# Altium schematic sheet InductiveSensor.SchDoc
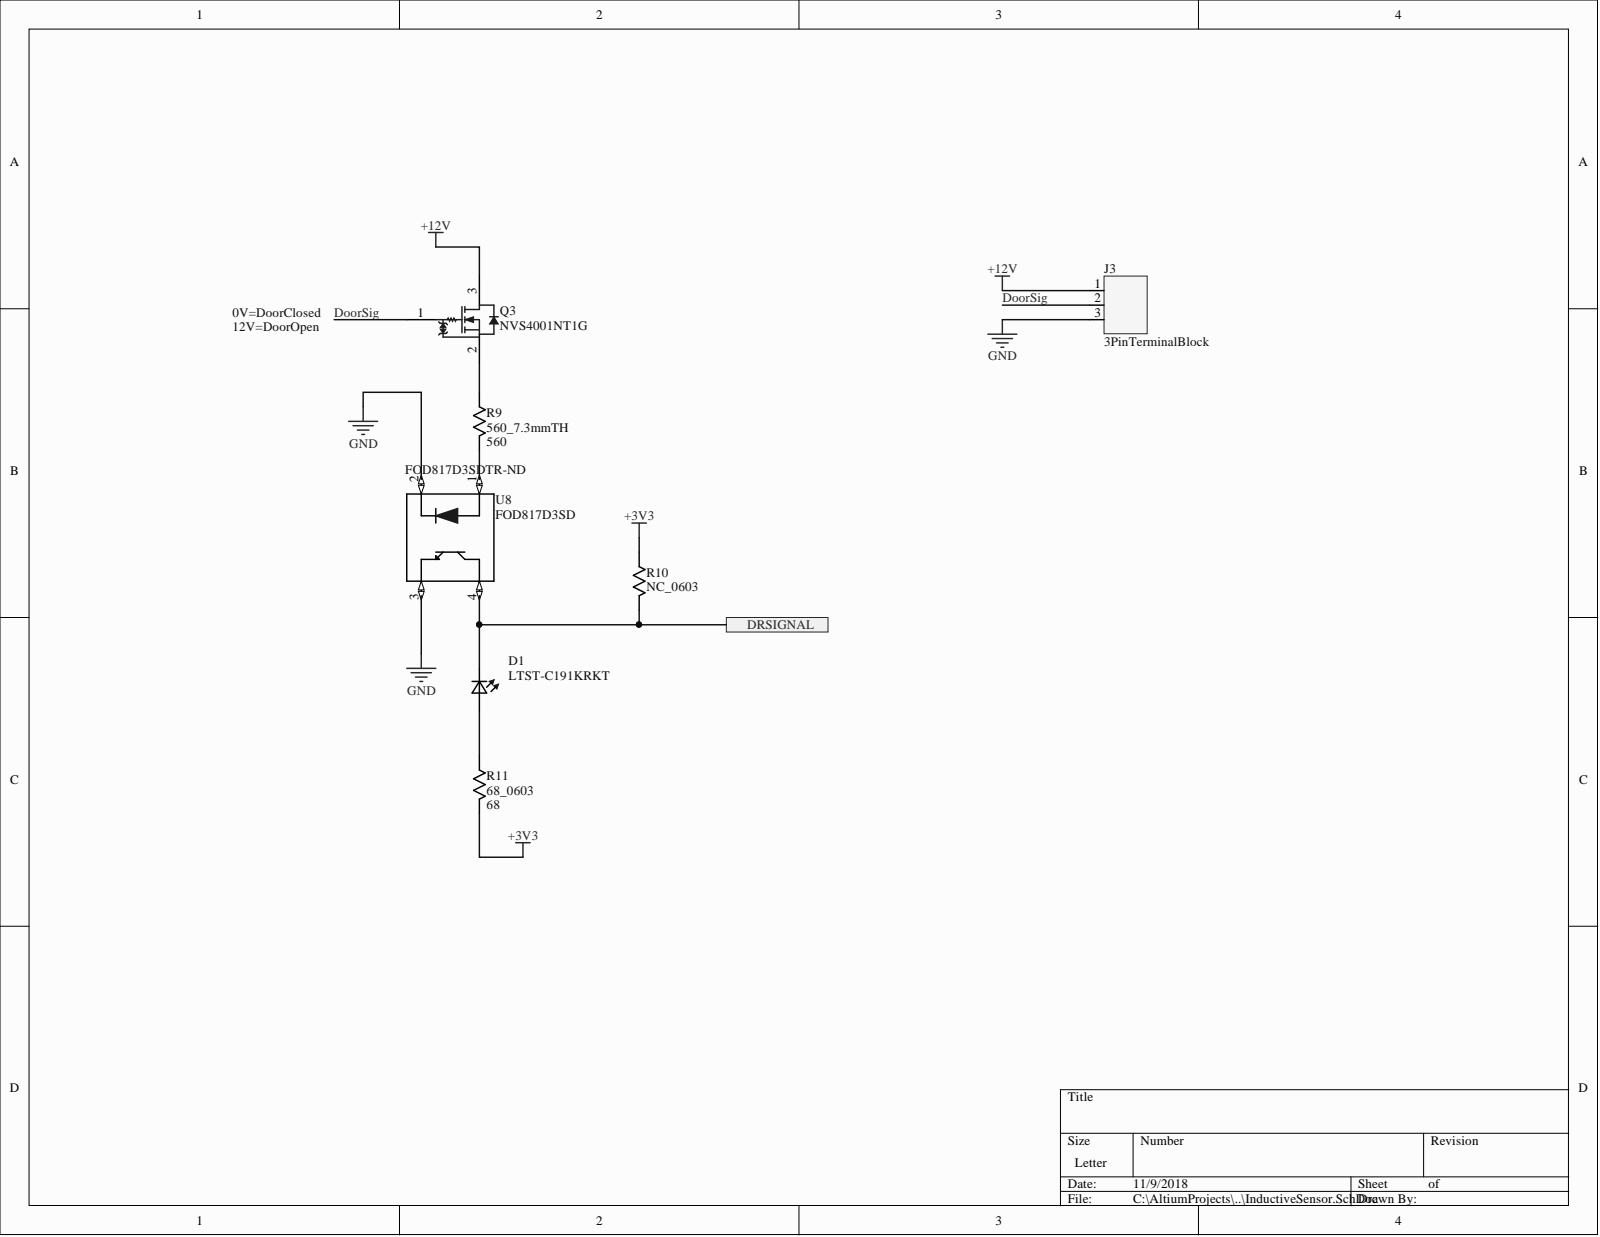
[diagram p0: InductiveSensor.SchDoc]

## Parts
D1  LTST-C191KRKT  [0603]
J3  3PinTerminalBlock  [3 POS 5mm Pitch]
Q3  NVS4001NT1G  [ONSC-SC-70-3-419-04_V]
R9  560_7.3mmTH  [7.3mm Through Hole]
R10  NC_0603  [0603]
R11  68_0603  [0603]
U8  FOD817D3SD  Supplier Part Number 1: FOD817D3SDTR-ND  [FOD817D3SD]

## Ports
DRSIGNAL

## Nets
+3V3: R10.2 R11.1
+12V: J3.1 Q3.3
GND: J3.3 U8.2 U8.3
DoorSig: J3.2 Q3.1
DRSIGNAL: D1.1 R10.1 U8.4 ; port DRSIGNAL
NetD1_2: D1.2 R11.2
NetQ3_2: Q3.2 R9.2
NetR9_1: R9.1 U8.1

## Library data of the parts
D1 = LTST-C191KRKT: LED; SMD; 0603; red; 18-54mcd; 1.6x0.8x0.55mm; 130Â°; 2Ã·2.4V; 20mA; MF: Lite-On; Availability: Good; Component Kind: Standard; Library Name: Diodes.IntLib; MP: LTST-C191KRKT; Package: 0603 Lite-On; Price: 0.06 USD; SnapEDA_Link: https://www.snapeda.com/parts/LTST-C191KRKT/Lite-On/view-part/?ref=snap
J3 = 3PinTerminalBlock: 3 Position terminal block; Component Kind: Standard; Library Name: Connectors.IntLib
Q3 = NVS4001NT1G: Small Signal MOSFET, 30 V, 270 mA, N-Channel, 3-Pin SC70, Pb-Free, Tape and Reel; Manufacturer: On Semiconductor; Channel Polarity: N Channel; Ciss Typ (pF): 20; Configuration: Single; Coss Typ (pF): 19; Crss Typ (pF): 7.25; ID Max (A): 0.27; PD Max (W): 0.33; V(BR)DSS Min (V): 30; VGS(th) Max (V): 1.5; Component Kind: Standard; ComponentLink1Description: Manufacturer URL; ComponentLink1URL: http://www.onsemi.com/; ComponentLink2Description: Datasheet; ComponentLink2URL: http://www.onsemi.cn/pub_link/Collateral/NTS4001N-D.PDF; ComponentLink3Description: Package Specification; ComponentLink3URL: http://www.onsemi.cn/pub_link/Collateral/419-04.PDF; DatasheetVersion: Rev. 5; Library Name: ON Semi MOSFETs.IntLib; Mounting Technology: Surface Mount; PackageDescription: 3-Pin Small Outline Transistor, Body 2 x 1.25 mm, Pitch 0.65 mm; PackageReference: SC-70-3-419-04; Package Type: SC-70-3; PackageVersion: Rev. N, 11/2008; Packing: Tape and Reel; PartNumber: NVS4001NT1G; RoHS: Pb-Free; Supplier 1: Newark; Supplier 2: Mouser; Supplier Part Number 1: 60W1862; Supplier Part Number 2: 863-NVS4001NT1G; VGS Max (V): 20
R9 = 560_7.3mmTH: Manufacturer: Stackpole Electronics; Manufacturer Part Number: CFM12JT560R; Max Power Dissipation: 500mW; Resistance: 560; Temperature Coefficient: -400ppm/°C; Tolerance: 5%; Case/Package: Axial; Component Kind: Standard; ComponentLink1Description: https://www.seielect.com/Catalog/SEI-CF_CFM.pdf; ComponentLink1URL: https://www.seielect.com/Catalog/SEI-CF_CFM.pdf; Composition: Carbon Film; Contact Plating: Tin,Matte; Diameter: 2.3mm; Lead Free: Lead Free; Length: 0.256inch; Library Name: Resistors.IntLib; Max Operating Temperature: 155°C; Min Operating Temperature: -55°C; Mount: Through Hole,Axial; Number of Terminations: 2; Package Quantity: 5000; Packaging: Tape and Reel; Pricing 1: 5000=0.00703, 10000=0.00611, 25000=0.00537, 50000=0.00492, 125000=0.00482 (USD); Radiation Hardening: No; Stock 1: 0; Supplier 1: Digi-Key; Supplier Part Number 1: S560HTR-ND
R10 = NC_0603: Resistance: NC; Component Kind: Standard; Library Name: Resistors.IntLib
R11 = 68_0603: Manufacturer: Vishay; Manufacturer Part Number: CRCW060368R0FKEA; Max Power Dissipation: 100mW; Resistance: 68; Temperature Coefficient: 100ppm/°C; Tolerance: 1%; Voltage Rating: 75V; Case/Package: 0603; Case Code (Imperial): 0603; Case Code (Metric): 1608; Component Kind: Standard; ComponentLink1Description: https://datasheet.ciiva.com/2494/dcrcwe3-2494368.pdf; ComponentLink1URL: https://datasheet.ciiva.com/2494/dcrcwe3-2494368.pdf; ComponentLink2Description: https://datasheet.ciiva.com/11809/0900766b80d98afc-11809013.pdf; ComponentLink2URL: https://datasheet.ciiva.com/11809/0900766b80d98afc-11809013.pdf; ComponentLink3Description: https://datasheet.ciiva.com/8337/dcrcwe3-8337350.pdf; ComponentLink3URL: https://datasheet.ciiva.com/8337/dcrcwe3-8337350.pdf; ComponentLink4Description: https://datasheet.ciiva.com/7777/1697337-7777608.pdf; ComponentLink4URL: https://datasheet.ciiva.com/7777/1697337-7777608.pdf; ComponentLink5Description: https://datasheet.ciiva.com/16770/70201119-16770904.pdf; ComponentLink5URL: https://datasheet.ciiva.com/16770/70201119-16770904.pdf; ComponentLink6Description: https://datasheet.ciiva.com/2494/dcrcwe3-2494366.pdf; ComponentLink6URL: https://datasheet.ciiva.com/2494/dcrcwe3-2494366.pdf; ComponentLink7Description: https://datasheet.ciiva.com/22870/70201119-22870302.pdf; ComponentLink7URL: https://datasheet.ciiva.com/22870/70201119-22870302.pdf; ComponentLink8Description: https://datasheet.ciiva.com/2494/dcrcwe3-2494367.pdf; ComponentLink8URL: https://datasheet.ciiva.com/2494/dcrcwe3-2494367.pdf; ComponentLink9Description: https://datasheet.ciiva.com/16200/dcrcw-16200591.pdf; ComponentLink9URL: https://datasheet.ciiva.com/16200/dcrcw-16200591.pdf; Composition: Thick Film; Contact Plating: Tin; Depth: 0.85mm; Height: 0.45mm; Lead Free: Lead Free; Length: 1.55mm; Library Name: Resistors.IntLib; Max Operating Temperature: 155°C; Military Standard: Not; Min Operating Temperature: -55°C; Number of Terminations: 2; Package Quantity: 10; Packaging: Tape and Reel; Power Rating: 100mW; Pricing 1: 5000=0.00497, 10000=0.00432, 25000=0.00405 (USD); Radiation Hardening: No; REACH SVHC: Unknown; Stock 1: 0; Supplier 1: Digi-Key; Supplier Part Number 1: 541-68.0HTR-ND; Termination: SMD/SMT; Voltage Rating (DC): 75V; Weight: 7.1E-05oz; Width: 0.033inch
U8 = FOD817D3SD: OPTOISOLATOR 5KV TRANSISTOR 4SMD 20mA/1.2V saturation; Manufacturer: ON Semiconductor / Fairchild; Collector Emitter Saturation Voltage: 200mV; Current Transfer Ratio: 600%; Fall Time: 18000ns; Forward Current: 50mA; Forward Voltage: 1.4V; Max Output Voltage: 70V; Max Power Dissipation: 200mW; Number of Channels: 1; Reverse Breakdown Voltage: 6V; Case/Package: SMD/SMT; Collector Emitter Voltage (VCEO): 200mV; Component Kind: Standard; ComponentLink1Description: https://datasheet.ciiva.com/25620/0900766b810e24fa-25620565.pdf; ComponentLink1URL: https://datasheet.ciiva.com/25620/0900766b810e24fa-25620565.pdf; ComponentLink2Description: https://datasheet.ciiva.com/26122/fod817d-26122105.pdf; ComponentLink2URL: https://datasheet.ciiva.com/26122/fod817d-26122105.pdf; ComponentLink3Description: https://datasheet.ciiva.com/15118/getdatasheetpartid-67082-15118458.pdf; ComponentLink3URL: https://datasheet.ciiva.com/15118/getdatasheetpartid-67082-15118458.pdf; ComponentLink4Description: https://datasheet.ciiva.com/2086/fod817d-2086155.pdf; ComponentLink4URL: https://datasheet.ciiva.com/2086/fod817d-2086155.pdf; ComponentLink5Description: https://datasheet.ciiva.com/46433/1563587-46433595.pdf; ComponentLink5URL: https://datasheet.ciiva.com/46433/1563587-46433595.pdf; ComponentLink6Description: https://datasheet.ciiva.com/2086/fod817d-2086156.pdf; ComponentLink6URL: https://datasheet.ciiva.com/2086/fod817d-2086156.pdf; ComponentLink7Description: https://datasheet.ciiva.com/2086/fod817d-2086157.pdf; ComponentLink7URL: https://datasheet.ciiva.com/2086/fod817d-2086157.pdf; ComponentLink8Description: https://datasheet.ciiva.com/8095/fod817d-8095549.pdf; ComponentLink8URL: https://datasheet.ciiva.com/8095/fod817d-8095549.pdf; ComponentLink9Description: https://datasheet.ciiva.com/4701/fod817d-4701711.pdf; ComponentLink9URL: https://datasheet.ciiva.com/4701/fod817d-4701711.pdf; ComponentLink10Description: https://datasheet.ciiva.com/17001/fod817-17001647.pdf; ComponentLink10URL: https://datasheet.ciiva.com/17001/fod817-17001647.pdf; Input Current: 50mA; Isolation Voltage: 5kV; Lead Free: Lead Free; Manufacturer Part Number: FOD817D3SD; Max Collector Current: 50mA; Max Input Current: 50mA; Max Operating Temperature: 110°C; Min Operating Temperature: -55°C; Mount: Surface Mount; Number of Circuits: 1; Number of Elements: 1; Number of Pins: 4; Output Current per Channel: 50mA; Output Voltage: 70V; Package Quantity: 1; Packaging: Tape and Reel; Power Dissipation: 200mW; Pricing 1: 1000=0.15939, 2000=0.14611, 5000=0.13283, 10000=0.12397, 25000=0.11954, 50000=0.11512 (USD); Radiation Hardening: No; REACH SVHC: No SVHC; Rise Time: 18000ns; Stock 1: 123000; Supplier 1: Digi-Key; Weight: 0g; Library Name: Optocouplers.IntLib
